# Altium schematic sheet Stepper_Outputs.SchDoc
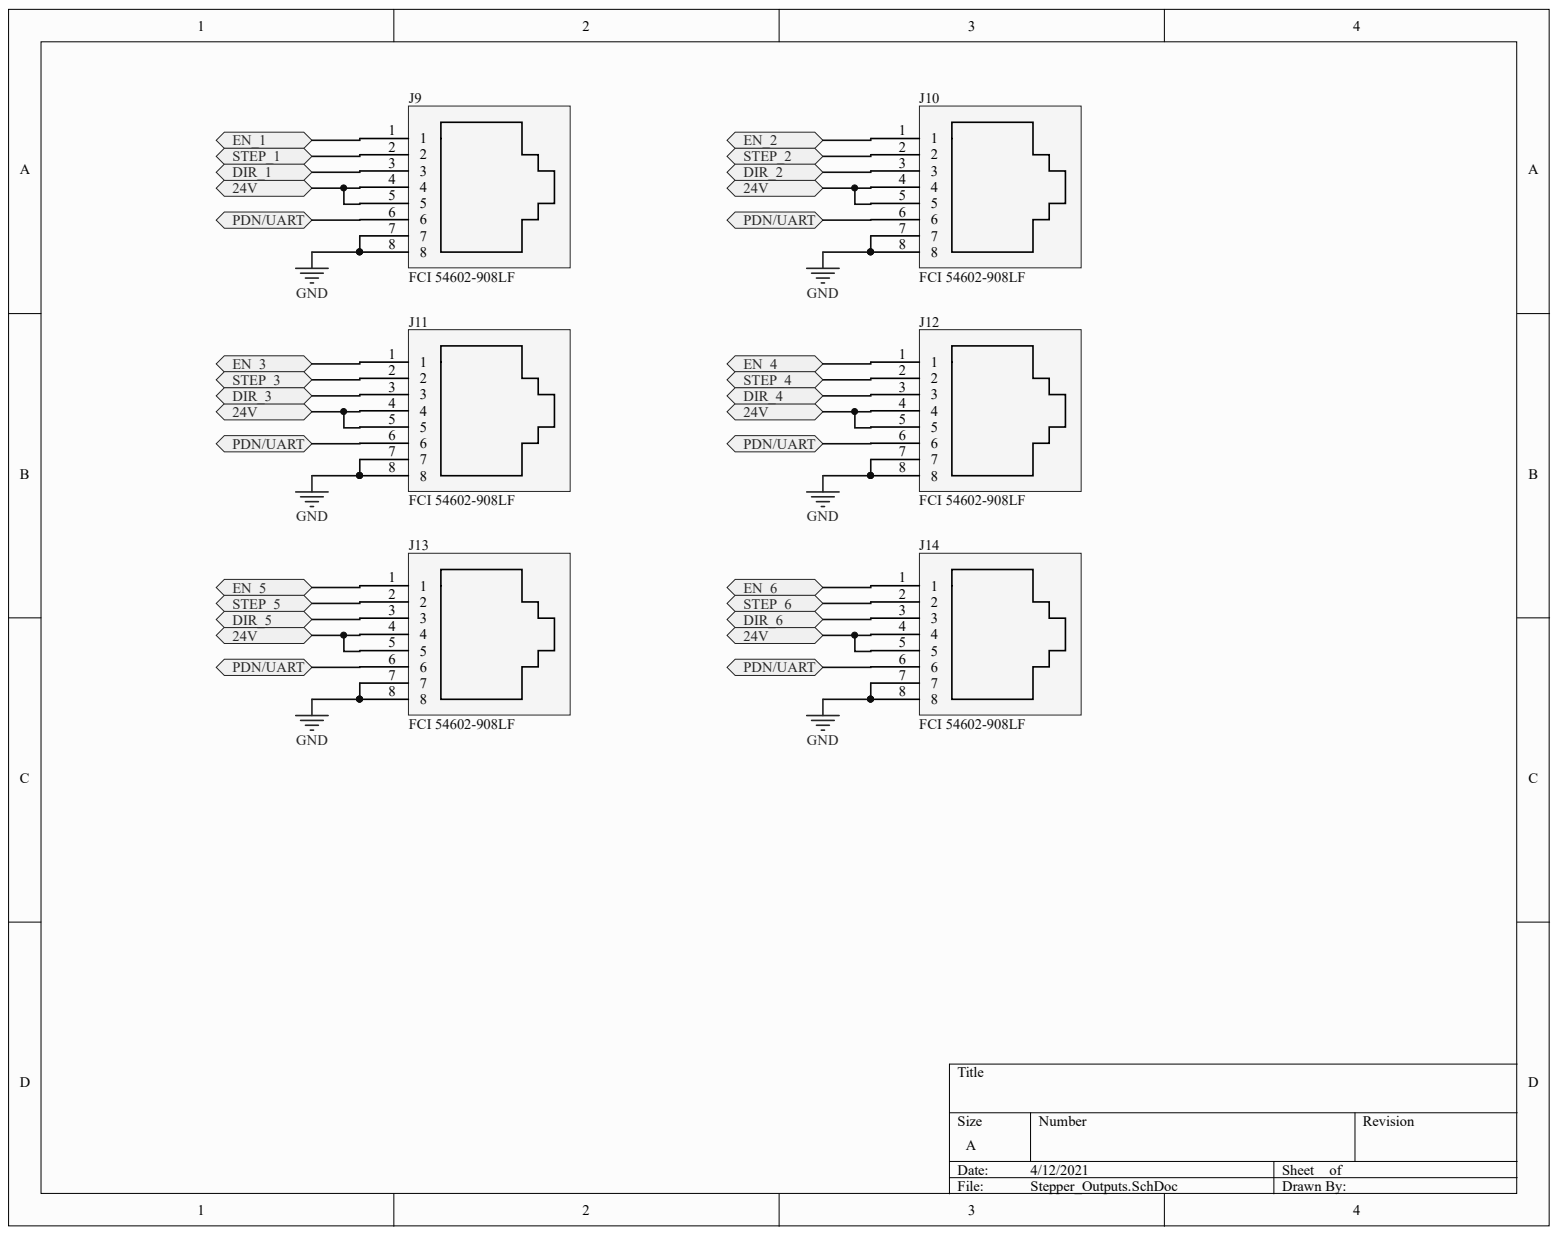
[diagram p0: Stepper_Outputs.SchDoc]

## Parts
J9  FCI 54602-908LF  Manufacturer Part Number: 54602-908LF  [PCB-kyusfhn5h9rxu8er00w0-1]
J10  FCI 54602-908LF  Manufacturer Part Number: 54602-908LF  [PCB-kyusfhn5h9rxu8er00w0-1]
J11  FCI 54602-908LF  Manufacturer Part Number: 54602-908LF  [PCB-kyusfhn5h9rxu8er00w0-1]
J12  FCI 54602-908LF  Manufacturer Part Number: 54602-908LF  [PCB-kyusfhn5h9rxu8er00w0-1]
J13  FCI 54602-908LF  Manufacturer Part Number: 54602-908LF  [PCB-kyusfhn5h9rxu8er00w0-1]
J14  FCI 54602-908LF  Manufacturer Part Number: 54602-908LF  [PCB-kyusfhn5h9rxu8er00w0-1]

## Ports
24V, DIR_1, DIR_2, DIR_3, DIR_4, DIR_5, DIR_6, EN_1, EN_2, EN_3, EN_4, EN_5, EN_6, PDN/UART, STEP_1, STEP_2, STEP_3, STEP_4, STEP_5, STEP_6

## Nets
GND: J9.7 J9.8 J10.7 J10.8 J11.7 J11.8 J12.7 J12.8 J13.7 J13.8 J14.7 J14.8
24V: J9.4 J9.5 J10.4 J10.5 J11.4 J11.5 J12.4 J12.5 J13.4 J13.5 J14.4 J14.5 ; port 24V
DIR_1: J9.3 ; port DIR_1
DIR_2: J10.3 ; port DIR_2
DIR_3: J11.3 ; port DIR_3
DIR_4: J12.3 ; port DIR_4
DIR_5: J13.3 ; port DIR_5
DIR_6: J14.3 ; port DIR_6
EN_1: J9.1 ; port EN_1
EN_2: J10.1 ; port EN_2
EN_3: J11.1 ; port EN_3
EN_4: J12.1 ; port EN_4
EN_5: J13.1 ; port EN_5
EN_6: J14.1 ; port EN_6
PDN/UART: J9.6 J10.6 J11.6 J12.6 J13.6 J14.6 ; port PDN/UART
STEP_1: J9.2 ; port STEP_1
STEP_2: J10.2 ; port STEP_2
STEP_3: J11.2 ; port STEP_3
STEP_4: J12.2 ; port STEP_4
STEP_5: J13.2 ; port STEP_5
STEP_6: J14.2 ; port STEP_6

## Library data of the parts
J9-J14 = CMP-1a15d1442034c5d2-8: Conn Modular Jack F 8 POS 2.54mm Solder RA Thru-Hole 8 Terminal 1 Port Box Cat 3; Gender: Male; Lead-Free Status: Lead Free; Number of Contacts: 8; Color: Black; Component Kind: Standard; Contact Material: Phosphor Bronze; Contact Plating: Gold; Library Name: CommunityVault; Mounting Style: Through Hole, PCB; OctopartID: 1a15d1442034c5d2; Octopart URL: http://octopart.com/54602-908lf-fci-39526215; REACH SVHC Compliance: No SVHC; RoHS: Compliant; Size-Height: 12.7 mm; Size-Length: 15.3 mm; Size-Width: 17.7 mm
